# Altium schematic sheet I301X.SchDoc
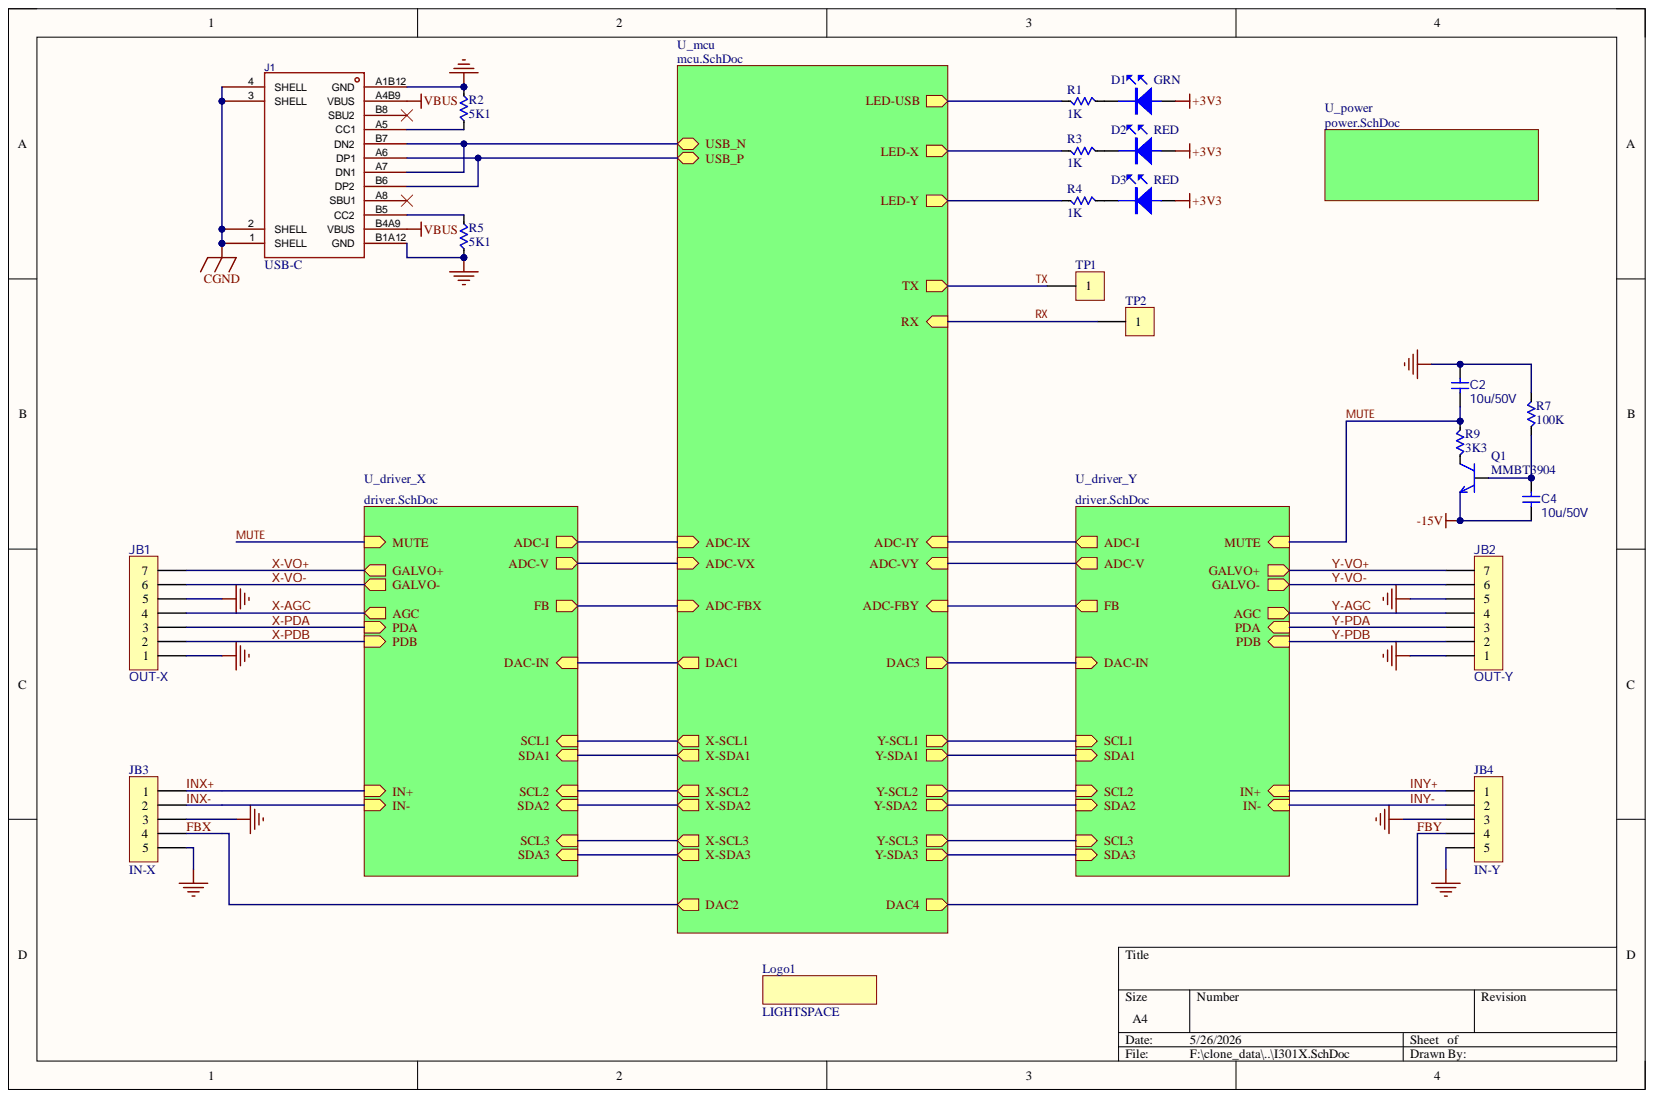
[diagram p0: I301X.SchDoc]

## Parts
C2  10u/50V  [1206C]
C4  10u/50V  [1206C]
D1  GRN  [0805-LED]
D2  RED  [0805-LED]
D3  RED  [0805-LED]
J1  USB-C  [USB-C-SMD_GT-USB-7010ASV]
JB1  OUT-X  [YX-XH-7A]
JB2  OUT-Y  [YX-XH-7A]
JB3  IN-X  [ZH8A1501-WV05]
JB4  IN-Y  [ZH8A1501-WV05]
Logo1  LIGHTSPACE  [LOGO-LIGHTSPACE]
Q1  MMBT3904  [SOT-23]
R1  1K  [0603R]
R2  5K1  [0603R]
R3  1K  [0603R]
R4  1K  [0603R]
R5  5K1  [0603R]
R7  100K  [0603R]
R9  3K3  [0603R]
TP1  (no value)  [SMT-1]
TP2  (no value)  [SMT-1]

## Nets
+3V3: D1.1 D2.1 D3.1
-15V: C4.2 Q1.2
CGND: J1.1(SHELL) J1.2(SHELL) J1.3(SHELL) J1.4(SHELL)
GND: C2.1 J1.A1B12(GND) J1.B1A12(GND) JB1.1 JB1.5 JB2.1 JB2.5 JB3.3 JB3.5 JB4.3 JB4.5 R2.2 R5.2 R7.1
VBUS: J1.A4B9(VBUS) J1.B4A9(VBUS)
FBX: JB3.4
FBY: JB4.4
INX+: JB3.1
INX-: JB3.2
INY+: JB4.1
INY-: JB4.2
MUTE: C2.2 R9.2
RX: TP2.1
TX: TP1.1
X-AGC: JB1.4
X-PDA: JB1.3
X-PDB: JB1.2
X-VO+: JB1.7
X-VO-: JB1.6
Y-AGC: JB2.4
Y-PDA: JB2.3
Y-PDB: JB2.2
Y-VO+: JB2.7
Y-VO-: JB2.6
NetC4_1: C4.1 Q1.1 R7.2
NetD1_2: D1.2 R1.2
NetD2_2: D2.2 R3.2
NetD3_2: D3.2 R4.2
NetJ1_A5: J1.A5(CC1) R2.1
NetJ1_A6: J1.A6(DP1) J1.B6(DP2)
NetJ1_A7: J1.A7(DN1) J1.B7(DN2)
NetJ1_A8: J1.A8(SBU1)
NetJ1_B5: J1.B5(CC2) R5.1
NetJ1_B8: J1.B8(SBU2)
NetQ1_3: Q1.3 R9.1
NetR1_1: R1.1
NetR3_1: R3.1
NetR4_1: R4.1
ADC-FBX:
ADC-FBY:
ADC-I:
ADC-V:
DAC1:
DAC3:
SCL1:
SCL2:
SCL3:
SDA1:
SDA2:
SDA3:

## Library data of the parts
C2 C4 = CAP: Capacitor; Component Kind: Standard
D1-D3 = LED: light-emitting diode; Component Kind: Standard; Library Name: descrete.SchLib
J1 = USB-C-12PIN: GT-USB-7010ASV; Component Kind: Standard; Symbol: USB-C-SMD_GT-USB-7010ASV; Library Name: descrete.SchLib
JB1 JB2 = CON7: Connector; Component Kind: Standard
JB3 JB4 = CON5: Connector; Component Kind: Standard
Logo1 = VIRTUAL: Logo; Component Kind: Standard; Library Name: descrete.SchLib
Q1 = MMBT3904: NPN switching transistor; Component Kind: Standard; Library Name: transistor.SchLib
R1 R3 R4 = R0603SM: Resistor; Component Kind: Standard; Value: 1K
R2 R5 R7 R9 = RES2: Resistor; Component Kind: Standard; LatestRevisionDate: 17-Jul-2002; LatestRevisionNote: Re-released for DXP Platform.; PackageReference: AXIAL-0.3; Published: 8-Jun-2000; Library Name: descrete.SchLib; Publisher: Altium Limited
  R2 R5: Value: 5K1
  R7: Value: 100K
  R9: Value: 3K3
TP1 TP2 = CON1: Connector; Component Kind: Standard; Library Name: descrete.SchLib
